# Altium schematic sheet 01_Power_Supply.SchDoc(01_Power_Supply)
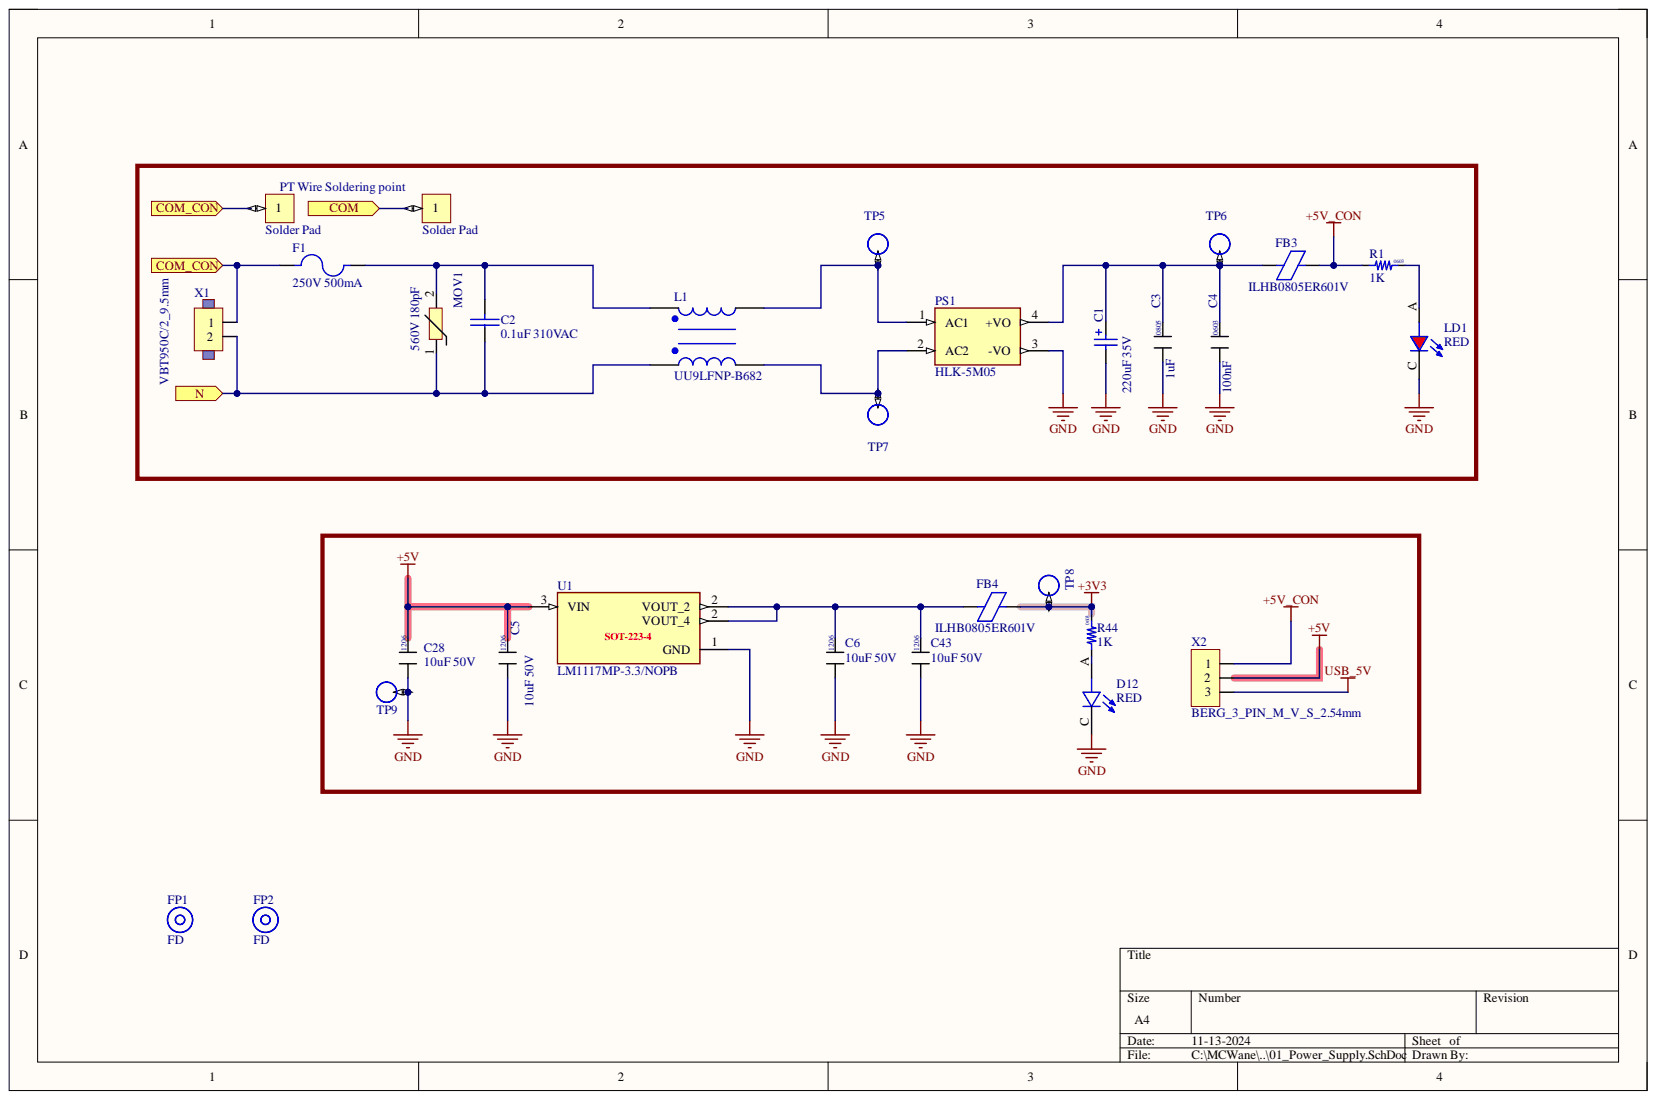
[diagram p0: 01_Power_Supply.SchDoc]

## Parts
C1  220uF 35V  [RC1V227M10010VR_10X10]
C2  0.1uF 310VAC  [Film Capacitors L13xW5,5xH11mm 100nF 400V]
C3  1uF  Package: 0805  [CAP_0805]
C4  100nF  Package: 0603  [CAP_0603]
C5  10uF 50V  Package: 1206  [CAP_1206]
C6  10uF 50V  Package: 1206  [CAP_1206]
C28  10uF 50V  Package: 1206  [CAP_1206]
C43  10uF 50V  Package: 1206  [CAP_1206]
D12  RED  [LED_0603]
F1  250V 500mA  [FUSE_5.08mm]
FB3  ILHB0805ER601V  [IND_0805]
FB4  ILHB0805ER601V  [IND_0805]
FP1  FD  [Fiducial_Point]
FP2  FD  [Fiducial_Point]
L1  UU9LFNP-B682  [UU9_8]
LD1  RED  [LED_0603]
MOV1  560V 180pF  [MOV_7.5mm]
PAD1  Solder Pad  [Soldering Pad_2mm]
PAD2  Solder Pad  [Soldering Pad_2mm]
PS1  HLK-5M05  [CONV_HLK-5M05]
R1  1K  Package: 0603  [RES_0603]
R44  1K  Package: 0603  [RES_0603]
TP5  TP  [TEST_POINT_SMD]
TP6  TP  [TEST_POINT_SMD]
TP7  TP  [TEST_POINT_SMD]
TP8  TP  [TEST_POINT_SMD]
TP9  TP  [TEST_POINT_SMD]
U1  LM1117MP-3.3/NOPB  Package: SOT-223-4  [SOT-223]
X1  VBT950C/2_9.5mm  [VBT950C/2_9.5mm]
X2  BERG_3_PIN_M_V_S_2.54mm  [BERG_3_PIN_M_V_S_2.54mm]

## Ports
COM, COM_CON, N

## Nets
+3V3: FB4.2 R44.2 TP8.1
+5V: C5.2 C28.2 U1.3 X2.2
+5V_CON: FB3.2 R1.1 X2.1
GND: C1.2 C3.1 C4.1 C5.1 C6.1 C28.1 C43.1 D12.C LD1.C PS1.3(-VO) TP9.1 U1.1
USB_5V: X2.3
COM: PAD2.1 ; port COM
COM_CON: F1.1 PAD1.1 X1.1 ; port COM_CON
N: C2.1 L1.1 MOV1.1 X1.2 ; port N
NetC1_1: C1.1 C3.2 C4.2 FB3.1 PS1.4(+VO) TP6.1
NetC2_2: C2.2 F1.2 L1.4 MOV1.2
NetC6_2: C6.2 C43.2 FB4.1 U1.2
NetD12_A: D12.A R44.1
NetL1_2: L1.2 PS1.2(AC2) TP7.1
NetL1_3: L1.3 PS1.1(AC1) TP5.1
NetLD1_A: LD1.A R1.2

Not connected: FP1 1; FP2 1

## Library data of the parts
C1 = RC1V227M10010VR: Aluminium Electrolytic Capacitors - SMD, 220uf/35V, DxL10×10mm; Brand: SAMWHA; Component Kind: Standard; Library Name: Passive_Components.SchLib; Manufacturer Part Number: RC1V227M10010VR
C2 = MKP: 0.1uF 310VAC 10% X2 Pitch 10mm Metallized Polypropylene Film Capacitor; Manufacturer Part Number: X2-104K/310V-10MM; Brand: HEL; Component Kind: Standard; Library Name: Passive_Components.SchLib; Package: 10mm Pitch
C3 = C0805-1uF 50V-MLCC: SMD Multilayer Ceramic Capacitor, 1 µF, 50 V, 0805 [2012 Metric], ± 10%, X7R, -55 °C; Manufacturer Part Number: CC0805KKX7R9BB105; Brand: YAGEO; Marking: 105; Component: Capacitor; Component Kind: Standard; Library Name: Passive_Components.SchLib; Supplier: ELEMENT 14
C4 = C0603-0.1uF 50V-MLCC: SMD Multilayer Ceramic Capacitor, 0.1 µF, 50 V, 0603 [1608 Metric], ± 10%, X7R; Manufacturer Part Number: CC0603KRX7R9BB104; Brand: YAGEO; Marking: 104; Component: Capacitor; Component Kind: Standard; LCSC Part: C14663; Library Name: Passive_Components.SchLib; Supplier: ELEMENT 14
C5 C6 C28 C43 = C1206-10uF 50V-MLCC: SMD Multilayer Ceramic Capacitor, 10 µF, 50 V, 1206 [3216 Metric], ± 10%, X5R, GRT; Manufacturer Part Number: GRT31CR61H106KE01L; Brand: MURATA; Marking: 106; Component: Capacitor; Component Kind: Standard; Library Name: Passive_Components.SchLib; Supplier: ELEMENT 14
D12 LD1 = RED_LED_0603: 5mA 28.5mcd 2.2V 632nm Colorless transparence -40℃~+85℃ 617.5nm~633.5nm Red 120° 60mW 0603(LED); Manufacturer Part Number: 19-217/R6C-AL1M2VY/3T; Brand: Everlight Elec; Component Kind: Standard; Library Name: Active_Components.SchLib; Package: 0603
F1 = 40005000000: Fuses with Leads - Through Hole 250V IEC TL TE5 LL AMMO .500A; Brand: Littelfuse Inc.; Component Kind: Standard; Library Name: Active_Components.SchLib; Manufacturer Part Number: 40005000000; Package: (8.50 x 4.00 x 8.00mm)
FB3 FB4 = ILHB0805ER601V: Ferrite Bead, 0805 [2012 Metric], 600 ohm, 2 A, ILHB, 0.1 ohm, ± 25%; Brand: VISHAY; Component Kind: Standard; Library Name: Passive_Components.SchLib; Manufacturer Part Number: ILHB0805ER601V; Package: 0805
FP1 FP2 = Fiducial_Point: Component Kind: Standard; Library Name: CONNECTOR.SchLib
L1 = UU9LFNP-B682: 6.8 mH @ 1 kHz 2 Line Common Mode Choke Through Hole 250mA DCR 2.5Ohm; Manufacturer Part Number: 744212101; Brand: Würth Elektronik; Component Kind: Standard; LCSC Part #: C2662461; Library Name: Passive_Components.SchLib; Package: 6.5mm x3.6mm x 1.65mm; Supplier: Element14
MOV1 = HEL-10D561K: 560V 180pF MOV Varistor Through Hole; Manufacturer Part Number: MOV-10D561K; Brand: Bourns Inc.; Component Kind: Standard; Library Name: Active_Components.SchLib; Package: Disc 10mm
PAD1 PAD2 = Soldering Pad: Component Kind: Standard; Library Name: CONNECTOR.SchLib
PS1 = HLK-5M05: AC DC 220V to 5V 5W 5Watt Isolated Switching Step-Down Power Supply Module Converter; Brand: Hi-Link; Component Kind: Standard; Library Name: Active_Components.SchLib; Manufacturer Part Number: HLK-5M05; Package: 38 x 28 x 18mm
R1 R44 = R0603-1K-1%: SMD Chip Resistor, 1 kohm, ± 1%, 100 mW, 0603 [1608 Metric], Thick Film, General Purpose; Manufacturer Part Number: RC0603FR-071KL; Brand: YAGEO; Marking: 1001; Component: Resistor; Component Kind: Standard; LCSC Part: C109318; Library Name: Passive_Components.SchLib; Supplier: ELEMENT 14
TP5-TP9 = TEST_POINT_SMD: Component Kind: Standard; Library Name: CONNECTOR.SchLib
U1 = LM1117IMPX-3.3/NOPB: Fixed LDO Voltage Regulator, 15V in, 1.2V Dropout, 3.3V/800mA out, SOT-223-4; Brand: Texas Instruments; Component Kind: Standard; LCSC Part: C79401; Library Name: Active_Components.SchLib; Manufacturer Part Number: LM1117MP-3.3/NOPB
X1 = VBT950C/2_9.5mm: VITAL VBT 950 Barrier Terminal Block; Brand: Vital electrocomp; Component Kind: Standard; Library Name: CONNECTOR.SchLib; Manufacturer Part Number: VBT950C/2; Package: 9.5mm
X2 = BERG_3_PIN_M_V_S_2.54mm: Component Kind: Standard; Library Name: CONNECTOR.SchLib
